# Altium schematic sheet AVALON._power2.SchDoc(AVALON._power2)
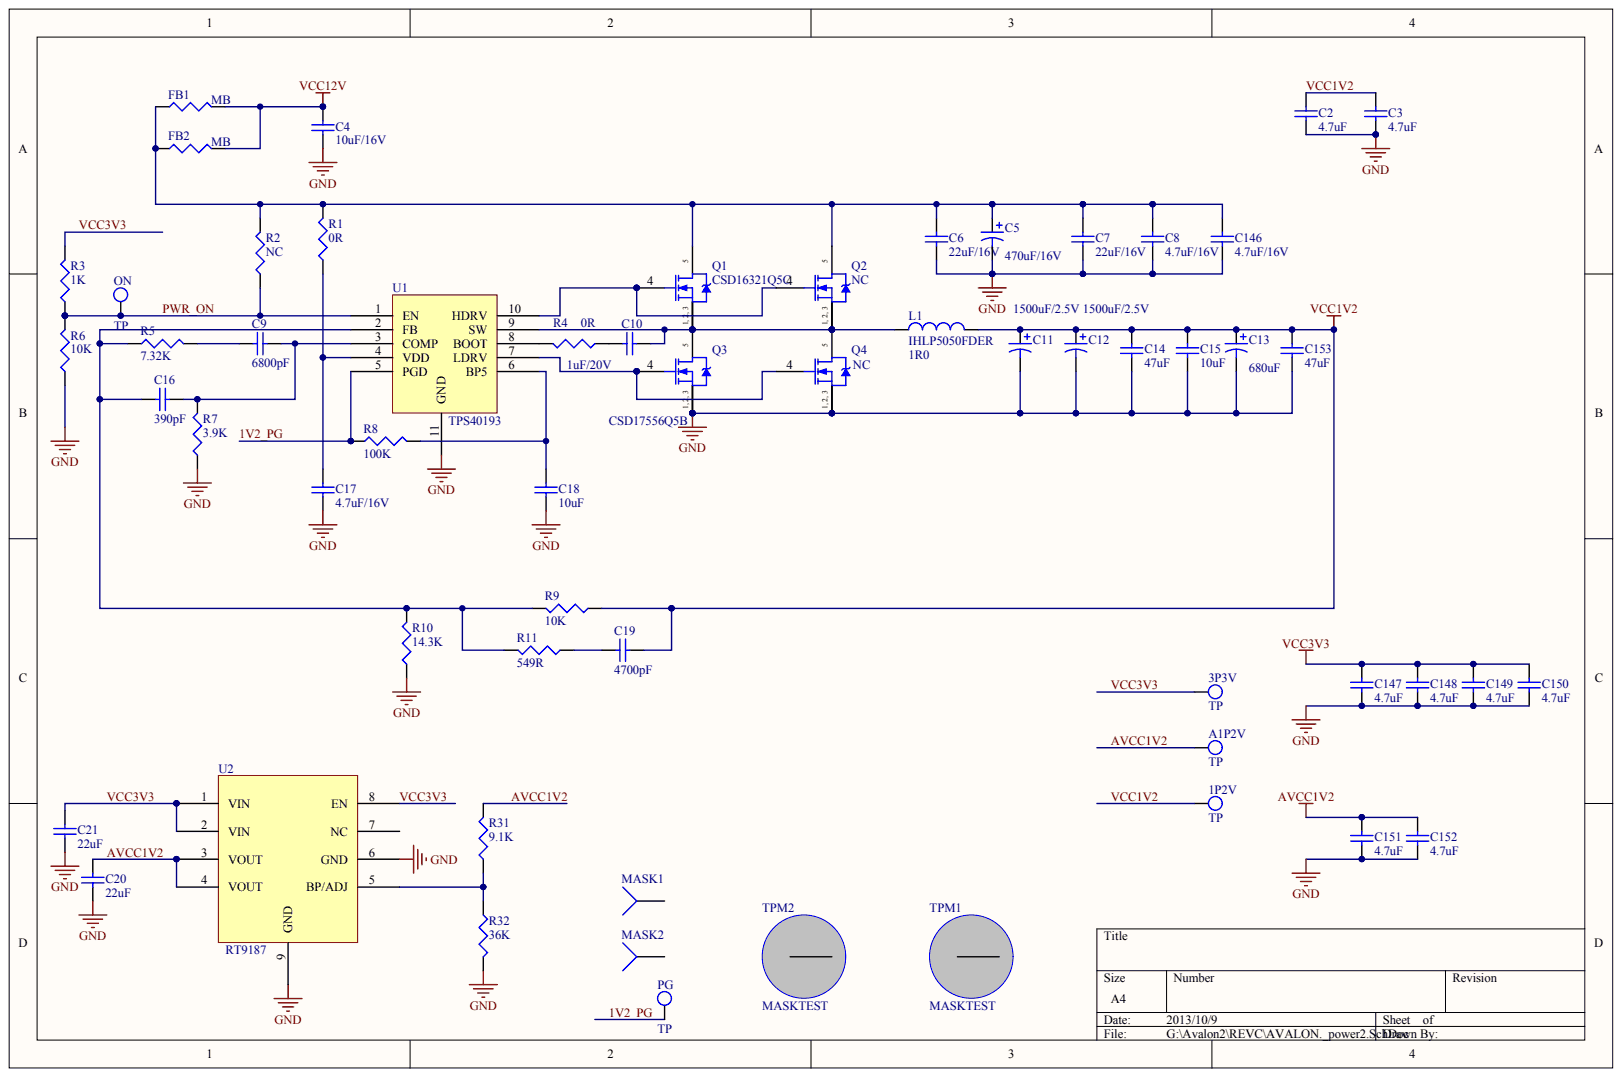
[diagram p0: AVALON._power2.SchDoc]

## Parts
1P2V  TP
3P3V  TP
A1P2V  TP
C2  4.7uF
C3  4.7uF
C4  10uF/16V
C5  470uF/16V
C6  22uF/16V
C7  22uF/16V
C8  4.7uF/16V
C9  6800pF
C10  1uF/20V
C11  1500uF/2.5V
C12  1500uF/2.5V
C13  680uF
C14  47uF
C15  10uF
C16  390pF
C17  4.7uF/16V
C18  10uF
C19  4700pF
C20  22uF
C21  22uF
C146  4.7uF/16V
C147  4.7uF
C148  4.7uF
C149  4.7uF
C150  4.7uF
C151  4.7uF
C152  4.7uF
C153  47uF
FB1  MB
FB2  MB
L1  IHLP5050FDER  value 1R0
MASK1  Socket  (value not drawn)
MASK2  Socket  (value not drawn)
ON  TP
PG  TP
Q1  CSD16321Q5C
Q2  NC
Q3  CSD17556Q5B
Q4  NC
R1  0R
R2  NC
R3  1K
R4  0R
R5  7.32K
R6  10K
R7  3.9K
R8  100K
R9  10K
R10  14.3K
R11  549R
R31  9.1K
R32  36K
TPM1  MASKTEST
TPM2  MASKTEST
U1  TPS40193
U2  RT9187

## Nets
GND: C2.1 C3.1 C4.1 C5.2 C6.1 C7.1 C8.1 C11.2 C12.2 C13.2 C14.1 C15.1 C17.1 C18.2 C20.1 C21.2 C146.1 C147.1 C148.1 C149.1 C150.1 C151.1 C152.1 C153.1 Q3.1 Q3.2 Q3.3 Q4.1 Q4.2 Q4.3 R6.1 R7.1 R10.1 R32.2 U1.11(GND) U2.6(GND) U2.9(GND)
VCC12V: C4.2 FB1.2 FB2.2
1V2_PG: PG.1 R8.1 U1.5(PGD)
AVCC1V2: A1P2V.1 C20.2 C151.2 C152.2 R31.2 U2.3(VOUT) U2.4(VOUT)
PWR_ON: ON.1 R2.1 R3.1 R6.2 U1.1(EN)
VCC1V2: 1P2V.1 C2.2 C3.2 C11.1 C12.1 C13.1 C14.2 C15.2 C19.2 C153.2 L1.2 R9.1
VCC3V3: 3P3V.1 C21.1 C147.2 C148.2 C149.2 C150.2 R3.2 U2.1(VIN) U2.2(VIN) U2.8(EN)
NetC5_1: C5.1 C6.2 C7.2 C8.2 C146.2 FB1.1 FB2.1 Q1.5 Q2.5 R1.2 R2.2
NetC9_1: C9.1 C16.1 R7.2 U1.3(COMP)
NetC9_2: C9.2 R5.2
NetC10_1: C10.1 L1.1 Q1.1 Q1.2 Q1.3 Q2.1 Q2.2 Q2.3 Q3.5 Q4.5 U1.9(SW)
NetC10_2: C10.2 R4.1
NetC16_2: C16.2 R5.1 R9.2 R10.2 R11.2 U1.2(FB)
NetC17_2: C17.2 R1.1 U1.4(VDD)
NetC18_1: C18.1 R8.2 U1.6(BP5)
NetC19_1: C19.1 R11.1
NetQ1_4: Q1.4 Q2.4 U1.10(HDRV)
NetQ3_4: Q3.4 Q4.4 U1.7(LDRV)
NetR4_2: R4.2 U1.8(BOOT)
NetR31_1: R31.1 R32.1 U2.5(BP/ADJ)

Not connected: MASK1 1; MASK2 1; TPM1 0; TPM2 0; U2 7(NC)

## Library data of the parts
L1 = (no symbol name): Code_IEC: 1005; Published: 8-Jun-2000; LatestRevisionDate: 29-May-2009; LatestRevisionNote: IPC-7351 Footprint Added.; PackageReference: 0402-A; PackageDescription: Chip Inductor; Code_JEITA: 0402; Publisher: Altium Limited
1P2V 3P3V A1P2V C2-C21 C146-C153 FB1 FB2 MASK1 MASK2 ON PG Q1-Q4 R1-R11 R31 R32 TPM1 TPM2 U1 U2 = (no symbol name)
  C2 C3 C147-C152: Published: 8-Jun-2000; LatestRevisionDate: 29-May-2009; LatestRevisionNote: IPC-7351 Footprint Added.; PackageReference: C1206; PackageDescription: Chip Capacitor; Value: 4.7uF
  C4: Published: 8-Jun-2000; LatestRevisionDate: 29-May-2009; LatestRevisionNote: IPC-7351 Footprint Added.; PackageReference: C1206; PackageDescription: Chip Capacitor; Value: 10uF/16V
  C5: Published: 8-Jun-2000; LatestRevisionDate: 17-Jul-2002; LatestRevisionNote: Re-released for DXP Platform.; PackageReference: RB7.6-15; PackageDescription: Polarized Capacitor (Radial); 2 Leads; Value: 470uF/16V
  C6 C7: Published: 8-Jun-2000; LatestRevisionDate: 29-May-2009; LatestRevisionNote: IPC-7351 Footprint Added.; PackageReference: C1206; PackageDescription: Chip Capacitor; Value: 22uF/16V
  C8 C17 C146: Published: 8-Jun-2000; LatestRevisionDate: 29-May-2009; LatestRevisionNote: IPC-7351 Footprint Added.; PackageReference: C1206; PackageDescription: Chip Capacitor; Value: 4.7uF/16V
  C9: Published: 8-Jun-2000; LatestRevisionDate: 29-May-2009; LatestRevisionNote: IPC-7351 Footprint Added.; PackageReference: C1206; PackageDescription: Chip Capacitor; Value: 6800pF
  C10: Published: 8-Jun-2000; LatestRevisionDate: 29-May-2009; LatestRevisionNote: IPC-7351 Footprint Added.; PackageReference: C1206; PackageDescription: Chip Capacitor; Value: 1uF/20V
  C11 C12: Published: 8-Jun-2000; LatestRevisionDate: 17-Jul-2002; LatestRevisionNote: Re-released for DXP Platform.; PackageReference: RB7.6-15; PackageDescription: Polarized Capacitor (Radial); 2 Leads; Value: 1500uF/2.5V
  C13: Published: 8-Jun-2000; LatestRevisionDate: 29-May-2009; LatestRevisionNote: IPC-7351 Footprint Added.; PackageReference: C0805; PackageDescription: Chip Capacitor; Value: 680uF
  C14 C153: Published: 8-Jun-2000; LatestRevisionDate: 29-May-2009; LatestRevisionNote: IPC-7351 Footprint Added.; PackageReference: C1206; PackageDescription: Chip Capacitor; Value: 47uF
  C15 C18: Published: 8-Jun-2000; LatestRevisionDate: 29-May-2009; LatestRevisionNote: IPC-7351 Footprint Added.; PackageReference: C1206; PackageDescription: Chip Capacitor; Value: 10uF
  C16: Published: 8-Jun-2000; LatestRevisionDate: 29-May-2009; LatestRevisionNote: IPC-7351 Footprint Added.; PackageReference: C1206; PackageDescription: Chip Capacitor; Value: 390pF
  C19: Published: 8-Jun-2000; LatestRevisionDate: 29-May-2009; LatestRevisionNote: IPC-7351 Footprint Added.; PackageReference: C1206; PackageDescription: Chip Capacitor; Value: 4700pF
  C20 C21: Published: 8-Jun-2000; LatestRevisionDate: 29-May-2009; LatestRevisionNote: IPC-7351 Footprint Added.; PackageReference: C1206; PackageDescription: Chip Capacitor; Value: 22uF
  FB1 FB2: Published: 8-Jun-2000; LatestRevisionDate: 29-May-2009; LatestRevisionNote: IPC-7351 Footprint Added.; PackageReference: J1-0603; PackageDescription: Chip Resistor; Value: MB
  MASK1 MASK2: LatestRevisionDate: 17-Jul-2002; LatestRevisionNote: Re-released for DXP Platform.
  R1 R4: Published: 8-Jun-2000; LatestRevisionDate: 29-May-2009; LatestRevisionNote: IPC-7351 Footprint Added.; PackageReference: J1-0603; PackageDescription: Chip Resistor; Value: 0R
  R2: Published: 8-Jun-2000; LatestRevisionDate: 29-May-2009; LatestRevisionNote: IPC-7351 Footprint Added.; PackageReference: J1-0603; PackageDescription: Chip Resistor; Value: NC
  R3: Published: 8-Jun-2000; LatestRevisionDate: 29-May-2009; LatestRevisionNote: IPC-7351 Footprint Added.; PackageReference: J1-0603; PackageDescription: Chip Resistor; Value: 1K
  R5: Published: 8-Jun-2000; LatestRevisionDate: 29-May-2009; LatestRevisionNote: IPC-7351 Footprint Added.; PackageReference: J1-0603; PackageDescription: Chip Resistor; Value: 7.32K
  R6 R9: Published: 8-Jun-2000; LatestRevisionDate: 29-May-2009; LatestRevisionNote: IPC-7351 Footprint Added.; PackageReference: J1-0603; PackageDescription: Chip Resistor; Value: 10K
  R7: Published: 8-Jun-2000; LatestRevisionDate: 29-May-2009; LatestRevisionNote: IPC-7351 Footprint Added.; PackageReference: J1-0603; PackageDescription: Chip Resistor; Value: 3.9K
  R8: Published: 8-Jun-2000; LatestRevisionDate: 29-May-2009; LatestRevisionNote: IPC-7351 Footprint Added.; PackageReference: J1-0603; PackageDescription: Chip Resistor; Value: 100K
  R10: Published: 8-Jun-2000; LatestRevisionDate: 29-May-2009; LatestRevisionNote: IPC-7351 Footprint Added.; PackageReference: J1-0603; PackageDescription: Chip Resistor; Value: 14.3K
  R11: Published: 8-Jun-2000; LatestRevisionDate: 29-May-2009; LatestRevisionNote: IPC-7351 Footprint Added.; PackageReference: J1-0603; PackageDescription: Chip Resistor; Value: 549R
  R31: Published: 8-Jun-2000; LatestRevisionDate: 29-May-2009; LatestRevisionNote: IPC-7351 Footprint Added.; PackageReference: J1-0603; PackageDescription: Chip Resistor; Value: 9.1K
  R32: Published: 8-Jun-2000; LatestRevisionDate: 29-May-2009; LatestRevisionNote: IPC-7351 Footprint Added.; PackageReference: J1-0603; PackageDescription: Chip Resistor; Value: 36K
  C2-C21 C146-C153 FB1 FB2 MASK1 MASK2 R1-R11 R31 R32: Publisher: Altium Limited
